# Altium schematic sheet Sheet1.SchDoc(Sheet1)
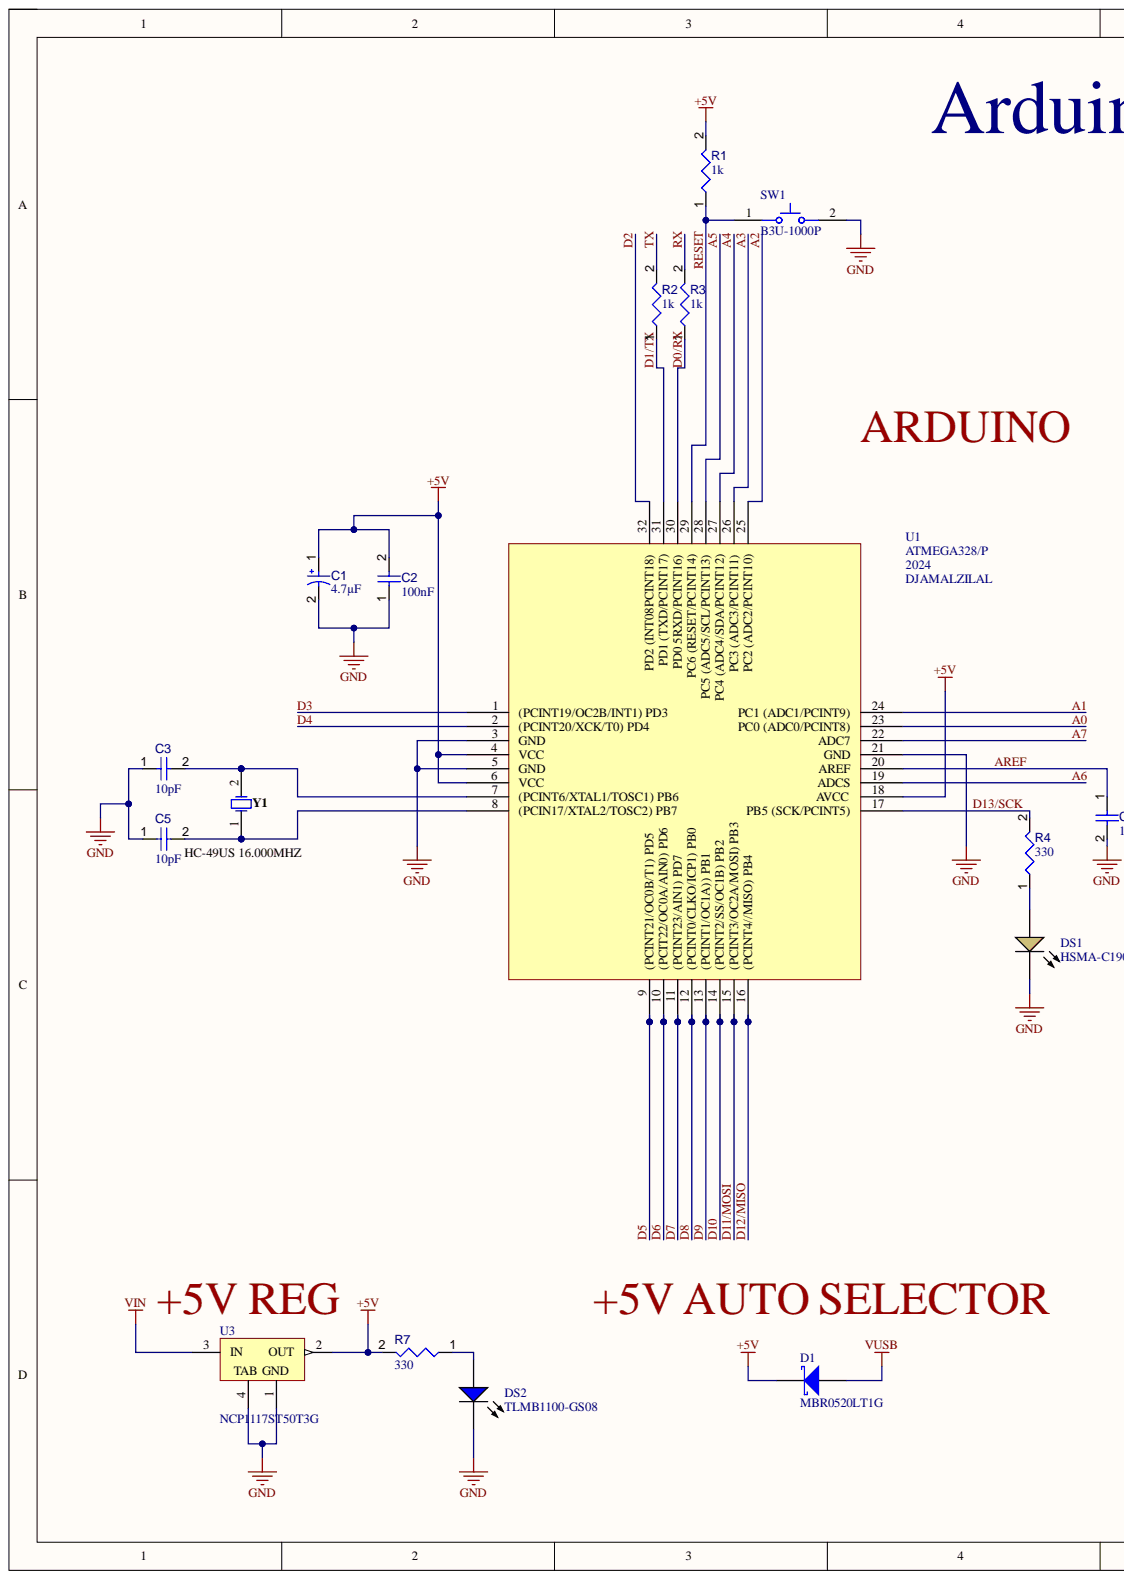
[diagram p0: Sheet1.SchDoc, left]
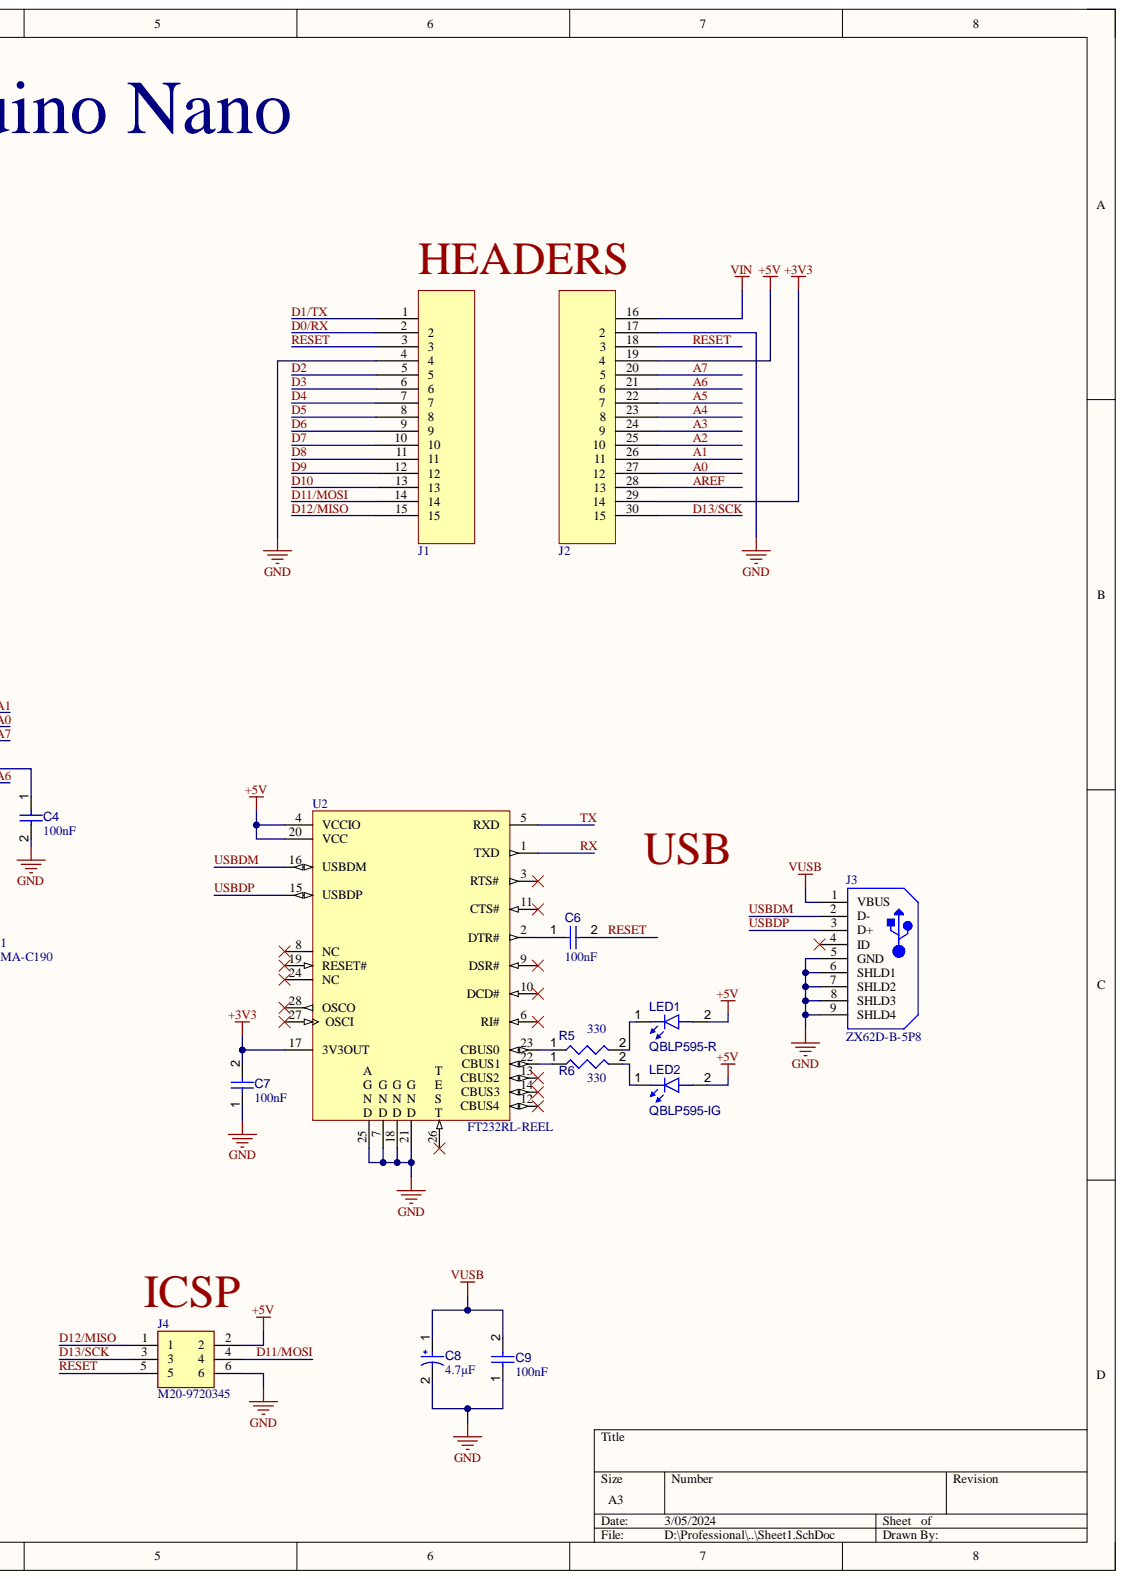
[diagram p1: Sheet1.SchDoc, right]

## Parts
C1  value 4.7µF  [CAPPR55-200-1100X500X1200]  (hidden comment: ECA1HM4R7)
C2  value 100nF  [CAPC1005X56X25LL10T15]  (hidden comment: Capacitor 0.1 uF +/- 5% 16 V 0402)
C3  value 10pF  [CAPC1005X55X30LL05T10]  (hidden comment: Capacitor 10 pF +/- 0.25 pF 50 V 0402)
C4  value 100nF  [CAPC1005X56X25LL10T15]  (hidden comment: Capacitor 0.1 uF +/- 5% 16 V 0402)
C5  value 10pF  [CAPC1005X55X30LL05T10]  (hidden comment: Capacitor 10 pF +/- 0.25 pF 50 V 0402)
C6  value 100nF  [CAPC1005X56X25LL10T15]  (hidden comment: Capacitor 0.1 uF +/- 5% 16 V 0402)
C7  value 100nF  [CAPC1005X56X25LL10T15]  (hidden comment: Capacitor 0.1 uF +/- 5% 16 V 0402)
C8  value 4.7µF  [CAPPR55-200-1100X500X1200]  (hidden comment: ECA1HM4R7)
C9  value 100nF  [CAPC1005X56X25LL10T15]  (hidden comment: Capacitor 0.1 uF +/- 5% 16 V 0402)
D1  MBR0520LT1G  [ONSC-SOD-123-2-425-04_V]
DS1  HSMA-C190  [AVAG-HSMX-C190_V]
DS2  TLMB1100-GS08  [VISH-TLMX1100-2_V]
J1  both parts are inseparated  [PCBComponent_1]  (value not drawn)
J2  both parts are inseparated  [PCBComponent_1]  (value not drawn)
J3  ZX62D-B-5P8  [HIRO-ZX62D-B-5P8_V]
J4  M20-9720345  [HDRV6W64P254_2X3_762X508X864P]
LED1  QBLP595-R  [QTB-QBLP595_V]
LED2  QBLP595-IG  [QTB-QBLP595_V]
R1  value 1k  [RESC0402(1005)_L]  (hidden comment: 1KR2J)
R2  value 1k  [RESC0402(1005)_L]  (hidden comment: 1KR2J)
R3  value 1k  [RESC0402(1005)_L]  (hidden comment: 1KR2J)
R4  value 330  [RESC0402(1005)_L]  (hidden comment: 330R2J)
R5  value 330  [RESC0402(1005)_L]  (hidden comment: 330R2J)
R6  value 330  [RESC0402(1005)_L]  (hidden comment: 330R2J)
R7  value 330  [RESC0402(1005)_L]  (hidden comment: 330R2J)
SW1  B3U-1000P  [OMRON-B3U-1000_V]
U1  ATMEGA328/P  CREATED By: DJAMALZILAL  DATA CREATED: 2024  [ATMEGA328/P]
U2  FT232RL-REEL  [FTDI-SSOP-28_M]
U3  NCP1117ST50T3G  [ONSC-SOT-223-4-318H-01_A_V]
Y1  HC-49US 16.000MHZ  Case/Package: HC-49US  [JPY-HC-49US]

## Nets
+3V3: C7.2 J2.29 U2.17(3V3OUT)
+5V: C1.1 C2.2 D1.2 J2.19 J4.2 LED1.2 LED2.2 R1.2 R7.2 U1.4(VCC) U1.6(VCC) U1.18(AVCC) U2.4(VCCIO) U2.20(VCC) U3.2(OUT)
GND: C1.2 C2.1 C3.1 C4.2 C5.1 C7.1 C8.2 C9.1 DS1.2 DS2.2 J1.4 J2.17 J3.5(GND) J3.6(SHLD1) J3.7(SHLD2) J3.8(SHLD3) J3.9(SHLD4) J4.6 SW1.2 U1.3(GND) U1.5(GND) U1.21(GND) U2.7(D_N_G) U2.18(D_N_G) U2.21(D_N_G) U2.25(D_N_G_A) U3.1 U3.4
VIN: J2.16 U3.3(IN)
VUSB: C8.1 C9.2 D1.1 J3.1(VBUS)
A0: J2.27 U1.23(PC0_(ADC0/PCINT8))
A1: J2.26 U1.24(PC1_(ADC1/PCINT9))
A2: J2.25 U1.25(PC2_(ADC2/PCINT10))
A3: J2.24 U1.26(PC3_(ADC3/PCINT11))
A4: J2.23 U1.27(PC4_(ADC4/SDA/PCINT12))
A5: J2.22 U1.28(PC5_(ADC5/SCL/PCINT13))
A6: J2.21 U1.19(ADCS)
A7: J2.20 U1.22(ADC7)
AREF: C4.1 J2.28 U1.20(AREF)
D0/RX: J1.2 R3.1 U1.30(PD0_5RXD/PCINT16))
D1/TX: J1.1 R2.1 U1.31(PD1_(TXD/PCINT17))
D2: J1.5 U1.32(PD2_(INT08PCINT18))
D3: J1.6 U1.1((PCINT19/OC2B/INT1)_PD3)
D4: J1.7 U1.2((PCINT20/XCK/T0)_PD4)
D5: J1.8 U1.9((PCINT21/OC0B/T1)_PD5)
D6: J1.9 U1.10((PCIT22/OC0A/AIN0)_PD6)
D7: J1.10 U1.11((PCINT23/AIN1)_PD7)
D8: J1.11 U1.12((PCINT0/CLKO/ICP1)_PB0)
D9: J1.12 U1.13((PCINT1/OC1A))_PB1)
D10: J1.13 U1.14((PCINT2/SS/OC1B)_PB2)
D11/MOSI: J1.14 J4.4 U1.15((PCINT3/OC2A/MOSI)_PB3)
D12/MISO: J1.15 J4.1 U1.16((PCINT4//MISO)_PB4)
D13/SCK: J2.30 J4.3 R4.2 U1.17(PB5_(SCK/PCINT5))
RESET: C6.2 J1.3 J2.18 J4.5 R1.1 SW1.1 U1.29(PC6_(RESET/PCINT14))
RX: R3.2 U2.1(TXD)
TX: R2.2 U2.5(RXD)
USBDM: J3.2(D-) U2.16(USBDM)
USBDP: J3.3(D+) U2.15(USBDP)
NetC3_2: C3.2 U1.7((PCINT6/XTAL1/TOSC1)_PB6) Y1.2
NetC5_2: C5.2 U1.8((PCIN17/XTAL2/TOSC2)_PB7) Y1.1
NetC6_1: C6.1 U2.2(DTR#)
NetDS1_1: DS1.1 R4.1
NetDS2_1: DS2.1 R7.1
NetLED1_1: LED1.1 R5.2
NetLED2_1: LED2.1 R6.2
NetR5_1: R5.1 U2.23(CBUS0)
NetR6_1: R6.1 U2.22(CBUS1)

Not connected: J3 4(ID); U2 3(RTS#) 6(RI#) 8(NC) 9(DSR#) 10(DCD#) 11(CTS#) 12(CBUS4) 13(CBUS2) 14(CBUS3) 19(RESET#) 24(NC) 26(T_S_E_T) 27(OSCI) 28(OSCO)

## Library data of the parts
C1 C8 = CMP-001-00033-7: Aluminum Electrolytic Capacitor, 4.7 uF, +/- 20%, 50 V, -40 to 85 degC, 2-Pin THD, RoHS, Bulk; Manufacturer: Panasonic; Ripple Current: 45mA; Voltage Rating: 50V; Capacitor Type: Electrolytic; Case/Package: Radial; Component Kind: Standard; Datasheet URL: https://industrial.panasonic.com/cdbs/www-data/pdf/RDF0000/ABA0000C1218.pdf; Datasheet Version: Rev. 05, 10/2016; Diameter: 5mm; Lead Pitch: 2mm; Manufacturer URL: http://www.panasonic.com/; Max Operating Temperature: 85°C; Min Operating Temperature: -40°C; Mounting Technology: TH; Package Description: 2-Pin Radial Lead, Dia 5 mm, Height 12 mm; Package Quantity: 200; Package Reference: CAPPR55-200-1100X500X1200; Packaging: Bulk; Pins: 2; Ripple Current (AC): 45mA; RoHS Compliant: True; Tolerance: 20%; Library Name: Paris - Saclay University
C2 C4 C6 C7 C9 = CMP-001-00001-6: Chip Capacitor, 0.1 uF, +/- 5%, 16 V, 0402 (1005 Metric); Capacitor Type: Ceramic; Voltage Rating: 16V; Case/Package: 0402; Component Kind: Standard; Max Operating Temperature: 125°C; Min Operating Temperature: -55°C; Mounting Technology: SM; Pins: 2; RoHS Compliant: True; Tolerance: 5%; Library Name: Paris - Saclay University
C3 C5 = CMP-001-00011-6: Chip Capacitor, 10 pF, +/- 0.25 pF, 50 V, 0402 (1005 Metric); Capacitor Type: Ceramic; Tolerance: 0.25%; Voltage Rating: 50V; Case/Package: 0402; Component Kind: Standard; Max Operating Temperature: 125°C; Min Operating Temperature: -55°C; Mounting Technology: SM; Pins: 2; RoHS Compliant: True; Library Name: Paris - Saclay University
D1 = CMP-004-00003-2: Schottky Power Rectifier, 20 V, 5.5 A, -65 to 125 degC, 2-Pin SMD, RoHS, Tape and Reel; Manufacturer: ON Semiconductor; Elements: 1; Forward Current: 500mA; Forward Voltage: 385mV; Max Surge Current: 5.5A; Peak Reverse Current: 250uA; Case/Package: SOD; Component Kind: Standard; Datasheet URL: http://www.onsemi.cn/pub_link/Collateral/MBR0520LT1-D.PDF; Datasheet Version: Rev. 6, 01/2012; Manufacturer URL: http://www.onsemi.com/; Max Operating Temperature: 125°C; Min Operating Temperature: -65°C; Mounting Technology: SM; Package Description: 2-Pin Surface Mount Device, Body 2.69 x 1.6 mm; Package Quantity: 3000; Package Reference: SOD-123-2-425-04; Packaging: TapeandReel; Pins: 2; RoHS Compliant: True; Library Name: Paris - Saclay University
DS1 = CMP-007-00011-2: LED Uni-Color Amber, 60 mW, 20 mA, -40 to 85 degC, 2-Pin SMD, RoHS, Tape and Reel; Manufacturer: Avago; Colour: Orange; Forward Current: 20mA; Forward Voltage: 1.9V; Lens Style: Diffused; Luminous Intensity: 90mcd; Power: 60mW; Viewing Angle: 170degrees; Case/Package: 0603; Component Kind: Standard; Datasheet URL: http://docs.avagotech.com/docs/AV02-0112EN; Datasheet Version: Sep-10; Illumination Colour: Orange; Manufacturer URL: http://www.avagotech.com/; Max Operating Temperature: 85°C; Min Operating Temperature: -40°C; Mounting Technology: SM; Nominal Vf: 1.9V; Package Description: 2-Pin Surface Mount Device, Body 1.6 x 0.8 mm, Height 0.9 mm; Package Quantity: 4000; Package Reference: HSMX-C190; Packaging: TapeandReel; Pins: 2; RoHS Compliant: True; Library Name: Paris - Saclay University
DS2 = CMP-007-00028-2: Blue LED, 10 mA, 3.9 V, -40 to 100 degC, 2-Pin SMD (0603), RoHS, Tape and Reel; Manufacturer: Vishay Semiconductor; Forward Current: 10mA; Forward Voltage: 3.9V; Lens Style: Diffused; Luminous Intensity: 5mcd; Power: 68mW; Viewing Angle: 160degrees; Case/Package: 0603; Colour: Blue; Component Kind: Standard; Datasheet URL: http://download.siliconexpert.com/pdfs/2016/8/7/12/43/26/667/vsh_/manual/379263299541529tlmb1100.pdf; Datasheet Version: Rev. 2.7, 03/2016; Illumination Colour: Blue; Manufacturer URL: http://www.vishay.com/; Max Operating Temperature: 100°C; Min Operating Temperature: -40°C; Mounting Technology: SM; Nominal Vf: 3.9V; Package Description: 2-Pin Surface Mount Device, Body 1.6 x 0.8 mm; Package Quantity: 3000; Package Reference: 0603; Packaging: TapeandReel; Pins: 2; RoHS Compliant: True; Library Name: Paris - Saclay University
J1 J2 = Header 15: Component Kind: Standard; Library Name: Integrated_Library_Header_15.IntLib
J3 = CMP-002-00043-2: Right Angle Receptacle Connector, Micro USB, 5 Position, Height 2.65 mm, -30 to 85 degC, RoHS, Tape and Reel; Manufacturer: Hirose Electric; Current Rating: 1A; Gender: Male; Rows: 1; Case/Package: ZX62D-B-5P8; Component Kind: Standard; Connector Type: USB; Contact Material: Copper; Contact Plating: Gold; Datasheet URL: https://www.hirose.com/product/en/download_file/key_name/ZX62D-B-5P8/category/Catalog/doc_file_id/31704/?file_category_id=5&item_id=02420034000&is_series=; Datasheet Version: Aug-16; Housing Material: Plastic; Lead Pitch: 0.65mm; Manufacturer URL: https://www.hirose.com; Max Operating Temperature: 85°C; Min Operating Temperature: -30°C; Mounting Technology: SM; Package Description: USB Connector, 5 Position Surface Mount, Length 8 mm, Height 2.65 mm; Package Quantity: 2000; Package Reference: ZX62D-B-5P8; Package URL: https://www.hirose.com/product/en/download_file/key_name/ZX62D-B-5P8/category/Drawing%20(2D)/doc_file_id/36535/?file_category_id=6&item_id=02420034000&is_series=; Packaging: TapeandReel; Pins: 5; RoHS Compliant: True; Library Name: Paris - Saclay University
J4 = M20-9720345: Connector; Manufacturer_Name: Harwin; Component Kind: Standard; Datasheet Link: https://cdn.harwin.com/pdfs/M20-972.pdf; Height: 8.64mm; Manufacturer_Part_Number: M20-9720345; Mouser Part Number: 855-M20-9720345; Mouser Price/Stock: https://www.mouser.co.uk/ProductDetail/Harwin/M20-9720345?qs=vF78I%252BjhbY8b2N4EUBH%2Fyg%3D%3D
LED1 = CMP-007-00040-3: Chip LED 0402, Red, 0.02 A, 2.0 to 2.5 V, -40 to 80 degC, 2-Pin SMD, RoHS, Tape and Reel; Case/Package: 0402; Colour: Red; Component Kind: Standard; Mounting Technology: SMT; Pins: 2; RoHS Compliant: Yes; Library Name: Paris - Saclay University
LED2 = CMP-007-00041-3: Chip LED 0402, Green, 0.02 A, 3.1 to 3.7 V, -40 to 80 degC, 2-Pin SMD, RoHS, Tape and Reel; Case/Package: 0402; Colour: Green; Component Kind: Standard; Mounting Technology: SMT; Pins: 2; RoHS Compliant: Yes; Library Name: Paris - Saclay University
R1-R3 = CMP-009-00075-2: 1K 0.063W 5% 0402 (1005 Metric)  SMD; Power: 63mW; Case/Package: 0402; Component Kind: Standard; Mounting Technology: SMT; Pins: 2; Tolerance: 5%; Library Name: Paris - Saclay University
R4-R7 = CMP-009-00095-2: 330R 0.063W 5% 0402 (1005 Metric)  SMD; Power: 63mW; Case/Package: 0402; Component Kind: Standard; Mounting Technology: SMT; Pins: 2; Tolerance: 5%; Library Name: Paris - Saclay University
SW1 = CMP-011-00000-2: Tactile Switch, SPST-NO, 0.05 A, 12 V, -25 to 70 degC, 2-Pin SMD, RoHS, Tape and Reel; Manufacturer: Omron Electronic Components; Contact Current Rating: 50mA; IP Rating: IP40; Max Contact Resistance: 100mohm; Max Voltage Rating (DC): 12V; Operating Force: 153gf; Resistance: 0.1Ohm; Throw Configuration: SPST; Case/Package: B3U-1000; Component Kind: Standard; Contact Material: Silver; Current Rating: 50mA; Datasheet URL: http://www.omron.com/ecb/products/pdf/en-b3u.pdf; Datasheet Version: Jan-15; Manufacturer URL: http://www.omron.com/; Max Current Rating: 50mA; Max Operating Temperature: 70°C; Min Operating Temperature: -25°C; Mounting Technology: SM; Package Description: 2-Pin Surface Mount Device, Body 3 x 2.5 mm; Package Quantity: 3500; Package Reference: B3U-1000; Packaging: TapeandReel; RoHS Compliant: True; Voltage Rating: 12V; Voltage Rating (DC): 12V; Library Name: Paris - Saclay University
U1 = ATMEGA328/P: OrganizationName: Djamal_Organization; Component Kind: Standard; ComponentLink1Description: DATASHEET; ComponentLink1URL: https://ww1.microchip.com/downloads/en/DeviceDoc/Atmel-7810-Automotive-Microcontrollers-ATmega328P_Datasheet.pdf; DATASHEET: https://ww1.microchip.com/downloads/en/DeviceDoc/Atmel-7810-Automotive-Microcontrollers-ATmega328P_Datasheet.pdf; Library Name: Integrated_Library_ATmega328p.IntLib
U2 = CMP-015-00000-2: USB UART IC, -0.5 to 6 V, 24 mA, -40 to 85 degC, 28-Pin SSOP, RoHS, Tape and Reel; Manufacturer: Future Technology Devices International (FTDI); Channels: 1; Data Rate: 3Mbps; Max Supply Voltage: 5.25V; Min Supply Voltage: 3.3V; Nominal Supply Voltage: 5V; Case/Package: SSOP; Component Kind: Standard; Datasheet URL: http://datasheet.octopart.com/FT232RL-FTDI-datasheet-120501.pdf; Datasheet Version: Rev. 1.04, 02/2006; Interface: UART; Manufacturer URL: http://www.ftdichip.com/; Max Operating Temperature: 85°C; Min Operating Temperature: -40°C; Package Quantity: 2000; Packaging: TapeandReel; Pins: 16; RoHS Compliant: True; Library Name: Paris - Saclay University
U3 = CMP-019-00031-3: Low-Dropout Positive Fixed Voltage Regulator, 1 A, 5 V Vout, 0 to 125 degC, 4-Pin SOT-223, Pb-Free, Tape and Reel; Manufacturer: ON Semiconductor; Current Rating: -600A; Dropout Voltage: 1.2V; Max Input Voltage: 20V; Max Ouput Current: 1A; Min Input Voltage: 3.5V; Nominal Output Voltage: 5V; Outputs: 1; Case/Package: TO-261-4; Component Kind: Standard; Datasheet URL: https://datasheet.ciiva.com/28483/1748260-28483320.pdf; Datasheet Version: Rev. 26, 08/2013; Manufacturer URL: http://www.onsemi.com/; Max Operating Temperature: 125°C; Min Operating Temperature: 0°C; Mounting Technology: SM; Output Current: 1A; Output Voltage: 5V; Package Description: 4-Pin Small Outline Transistor, Body 6.5 x 3.5 mm, Pitch 2.3 mm; Package Quantity: 4000; Package Reference: SOT-223-4-318H-01_A; Packaging: TapeandReel; Pins: 3; RoHS Compliant: True; Library Name: Paris - Saclay University
Y1 = CMP-003-00005-2: Crystal, 16 MHz, +/- 30 ppm, 18 pF, -20 to 70 degC, 2-Pin THD; Manufacturer: Jing peng yuan; Frequency: 16MHz; Component Kind: Standard; Datasheet URL: https://statics3.seeedstudio.com/images/opl/datasheet/306010014.pdf; Datasheet Version: May-15; Mounting Technology: TH; Package Description: 2-Pin Throug Hole Device, Body 11.05 x 4.65 mm, Pitch 4.9 mm; Package Reference: HC-49US; RoHS Compliant: Unknown; Library Name: Paris - Saclay University
